# Altium schematic sheet amp.SchDoc(amp)
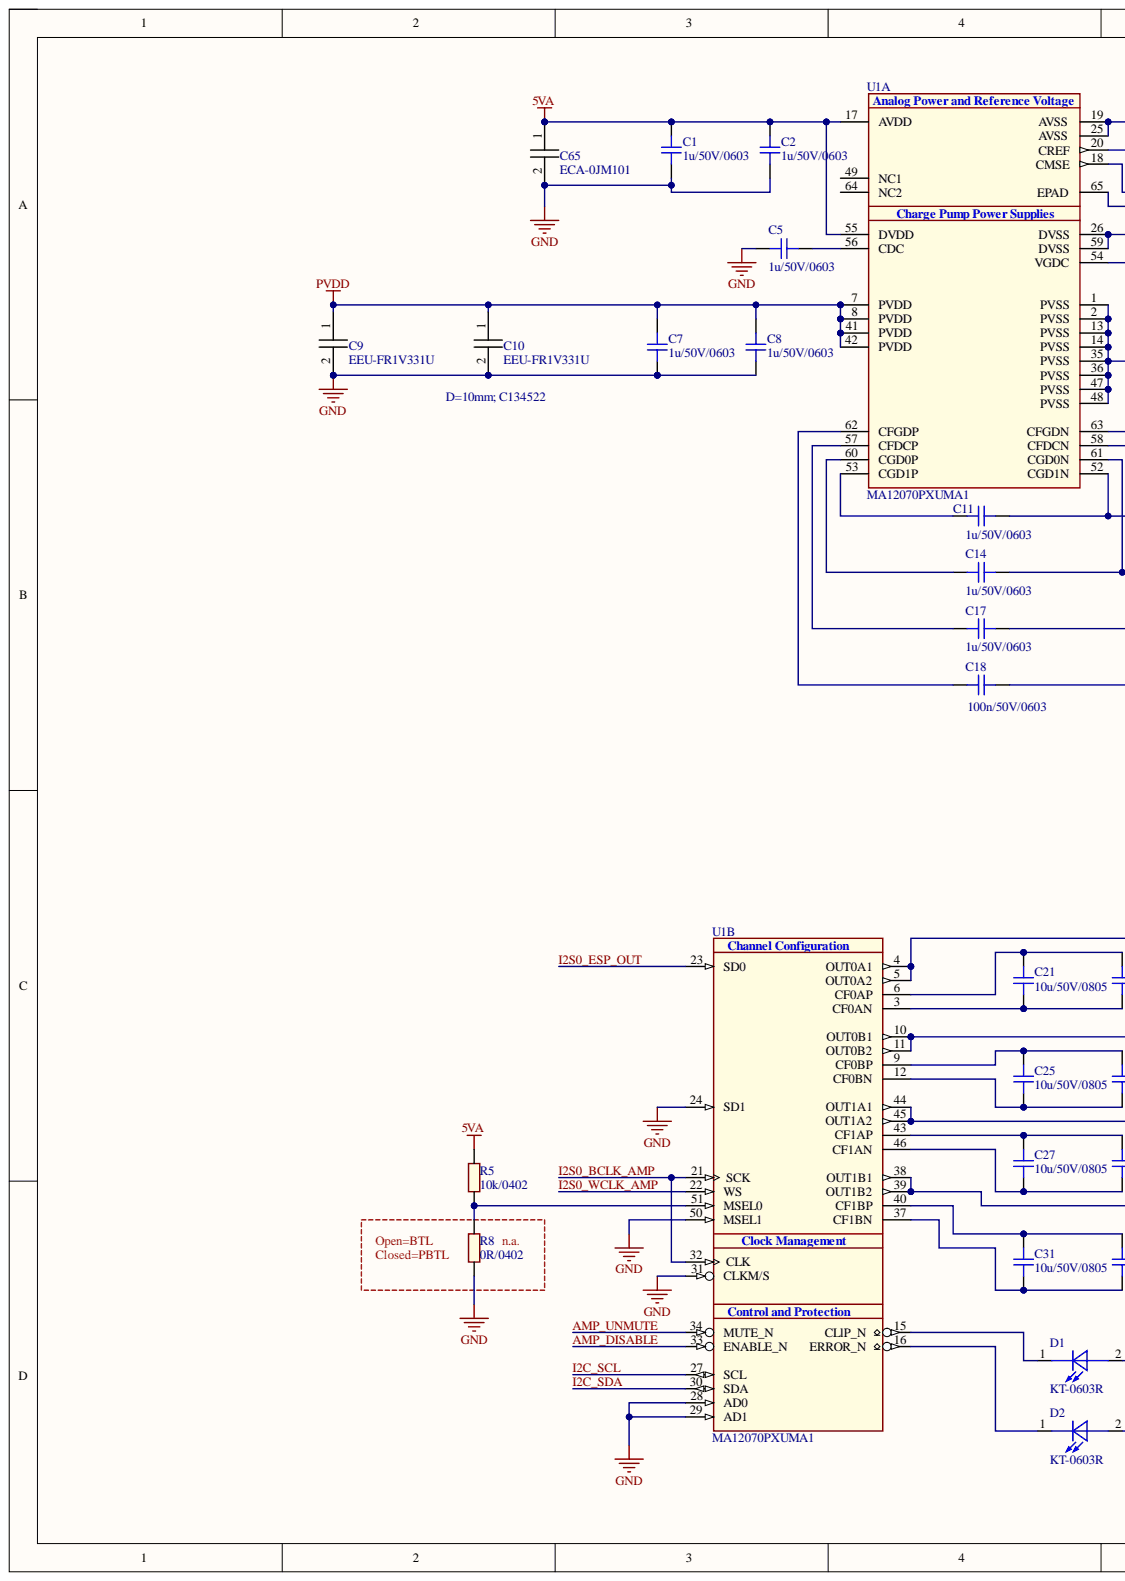
[diagram p0: amp.SchDoc, left]
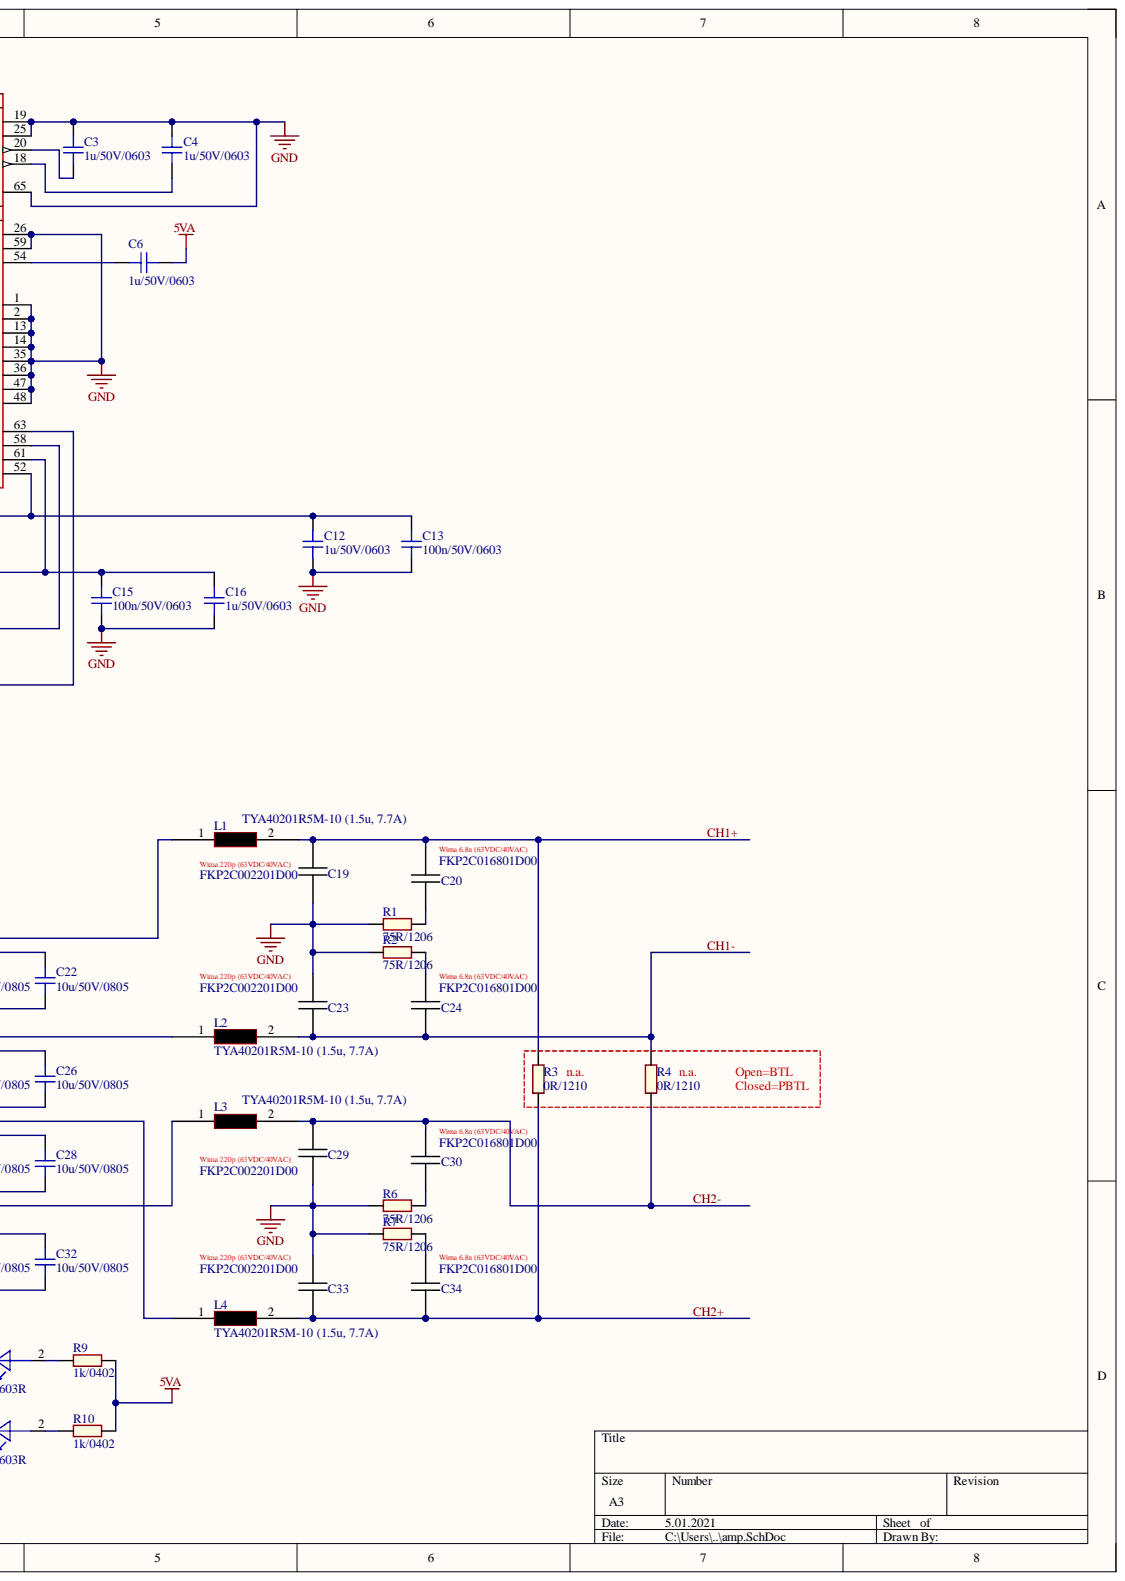
[diagram p1: amp.SchDoc, right]

## Parts
C1  1u/50V/0603  [CAPC1608X87N]
C2  1u/50V/0603  [CAPC1608X87N]
C3  1u/50V/0603  [CAPC1608X87N]
C4  1u/50V/0603  [CAPC1608X87N]
C5  1u/50V/0603  [CAPC1608X87N]
C6  1u/50V/0603  [CAPC1608X87N]
C7  1u/50V/0603  [CAPC1608X87N]
C8  1u/50V/0603  [CAPC1608X87N]
C9  EEU-FR1V331U  [CAP_THT_10mm_rm5mm]
C10  EEU-FR1V331U  [CAP_THT_10mm_rm5mm]
C11  1u/50V/0603  [CAPC1608X87N]
C12  1u/50V/0603  [CAPC1608X87N]
C13  100n/50V/0603  [CAPC1608X87N]
C14  1u/50V/0603  [CAPC1608X87N]
C15  100n/50V/0603  [CAPC1608X87N]
C16  1u/50V/0603  [CAPC1608X87N]
C17  1u/50V/0603  [CAPC1608X87N]
C18  100n/50V/0603  [CAPC1608X87N]
C19  FKP2C002201D00  [Wima FKP2 4.5x6x7.2]
C20  FKP2C016801D00  [Wima FKP2 4.5x6x7.2]
C21  10u/50V/0805  [CAPC2013X140N]
C22  10u/50V/0805  [CAPC2013X140N]
C23  FKP2C002201D00  [Wima FKP2 4.5x6x7.2]
C24  FKP2C016801D00  [Wima FKP2 4.5x6x7.2]
C25  10u/50V/0805  [CAPC2013X140N]
C26  10u/50V/0805  [CAPC2013X140N]
C27  10u/50V/0805  [CAPC2013X140N]
C28  10u/50V/0805  [CAPC2013X140N]
C29  FKP2C002201D00  [Wima FKP2 4.5x6x7.2]
C30  FKP2C016801D00  [Wima FKP2 4.5x6x7.2]
C31  10u/50V/0805  [CAPC2013X140N]
C32  10u/50V/0805  [CAPC2013X140N]
C33  FKP2C002201D00  [Wima FKP2 4.5x6x7.2]
C34  FKP2C016801D00  [Wima FKP2 4.5x6x7.2]
C65  ECA-0JM101  [CAP_THT_5mm_rm2mm]
D1  KT-0603R  [LEDSC160X80X80-2N]
D2  KT-0603R  [LEDSC160X80X80-2N]
L1  TYA40201R5M-10 (1.5u, 7.7A)  [Laird TYA4020 series]
L2  TYA40201R5M-10 (1.5u, 7.7A)  [Laird TYA4020 series]
L3  TYA40201R5M-10 (1.5u, 7.7A)  [Laird TYA4020 series]
L4  TYA40201R5M-10 (1.5u, 7.7A)  [Laird TYA4020 series]
R1  75R/1206  [RESC3216X60N]
R2  75R/1206  [RESC3216X60N]
R3  0R/1210  [RESC3225X70N-3]
R4  0R/1210  [RESC3225X70N-3]
R5  10k/0402  [RESC1005X40N]
R6  75R/1206  [RESC3216X60N]
R7  75R/1206  [RESC3216X60N]
R8  0R/0402  [RESC1005X40N]
R9  1k/0402  [RESC1005X40N]
R10  1k/0402  [RESC1005X40N]
U1  MA12070PXUMA1  [QFN50P900X900X90-65N-1]  (parts A,B)

## Nets
5VA: C1.2 C2.2 C6.1 C65.1 R5.2 R9.2 R10.2 U1.17(AVDD) U1.55(DVDD)
GND: C1.1 C2.1 C3.2 C4.2 C5.1 C7.2 C8.2 C9.2 C10.2 C12.1 C13.2 C15.2 C16.1 C19.1 C23.2 C29.1 C33.2 C65.2 R1.1 R2.1 R6.1 R7.1 R8.1 U1.1(PVSS) U1.2(PVSS) U1.13(PVSS) U1.14(PVSS) U1.19(AVSS) U1.24(SD1) U1.25(AVSS) U1.26(DVSS) U1.28(AD0) U1.29(AD1) U1.31(CLKM/S) U1.35(PVSS) U1.36(PVSS) U1.47(PVSS) U1.48(PVSS) U1.50(MSEL1) U1.59(DVSS) U1.65(EPAD)
PVDD: C7.1 C8.1 C9.1 C10.1 U1.7(PVDD) U1.8(PVDD) U1.41(PVDD) U1.42(PVDD)
AMP_DISABLE: U1.33(ENABLE_N)
AMP_UNMUTE: U1.34(MUTE_N)
CH1+: C19.2 C20.2 L1.2 R3.2
CH1-: C23.1 C24.1 L2.2 R4.2
CH2+: C33.1 C34.1 L4.2 R3.1
CH2-: C29.2 C30.2 L3.2 R4.1
I2C_SCL: U1.27(SCL)
I2C_SDA: U1.30(SDA)
I2S0_BCLK_AMP: U1.21(SCK) U1.32(CLK)
I2S0_ESP_OUT: U1.23(SD0)
I2S0_WCLK_AMP: U1.22(WS)
NetC3_1: C3.1 U1.20(CREF)
NetC4_1: C4.1 U1.18(CMSE)
NetC5_2: C5.2 U1.56(CDC)
NetC6_2: C6.2 U1.54(VGDC)
NetC11_1: C11.1 U1.53(CGD1P)
NetC11_2: C11.2 C12.2 C13.1 U1.52(CGD1N)
NetC14_1: C14.1 U1.60(CGD0P)
NetC14_2: C14.2 C15.1 C16.2 U1.61(CGD0N)
NetC17_1: C17.1 U1.57(CFDCP)
NetC17_2: C17.2 U1.58(CFDCN)
NetC18_1: C18.1 U1.63(CFGDN)
NetC18_2: C18.2 U1.62(CFGDP)
NetC20_1: C20.1 R1.2
NetC21_1: C21.1 C22.1 U1.3(CF0AN)
NetC21_2: C21.2 C22.2 U1.6(CF0AP)
NetC24_2: C24.2 R2.2
NetC25_1: C25.1 C26.1 U1.12(CF0BN)
NetC25_2: C25.2 C26.2 U1.9(CF0BP)
NetC27_1: C27.1 C28.1 U1.46(CF1AN)
NetC27_2: C27.2 C28.2 U1.43(CF1AP)
NetC30_1: C30.1 R6.2
NetC31_1: C31.1 C32.1 U1.37(CF1BN)
NetC31_2: C31.2 C32.2 U1.40(CF1BP)
NetC34_2: C34.2 R7.2
NetD1_1: D1.1 U1.15(CLlP_N)
NetD1_2: D1.2 R9.1
NetD2_1: D2.1 U1.16(ERROR_N)
NetD2_2: D2.2 R10.1
NetL1_1: L1.1 U1.4(OUT0A1) U1.5(OUT0A2)
NetL2_1: L2.1 U1.10(OUT0B1) U1.11(OUT0B2)
NetL3_1: L3.1 U1.38(OUT1B1) U1.39(OUT1B2)
NetL4_1: L4.1 U1.44(OUT1A1) U1.45(OUT1A2)
NetR5_1: R5.1 R8.2 U1.51(MSEL0)

Not connected: U1 49(NC1) 64(NC2)

## Library data of the parts
C1-C8 C11-C18 = 251321: CAP / CERA / 100nF / 10V / 5% / X7R (EIA) / -55°C to 125°C / 603(1608) / SMD / -; Manufacturer: Kemet; Manufacturer Order Number: C0603C104J8RAC; Alternate View: Yes; Automotive Qualified: No; Combined Parameters: TC:X7R (EIA);Tol.:-5%/+5%;Vdc:10V; ComponentStatus: Released; Database Table Name: TPartsAll; Legacy DeviceID: CAP00004163; Package Imperial: 603; Package Metric: 1608; PLMStatus: Not Recommended For New Designs; QuickPick: 100nF / 10V / 5% / X7R (EIA) / 0603; Style: Single; Symbol Type: Capacitor; Single; Standard; 2 Pins; Type: Standard; Version Number: V01; Article Number: 251321; Component Kind: Standard; ComponentLink1Description: Vendor WebSite; ComponentLink1URL: http://www.kemet.com; ComponentLink2Description: Datasheet; ComponentLink2URL: http://webnetprod.muc.infineon.com/PCBPortal_Prod/WorkFlows/AltiumComponent/StandardDocumentation?Versionid=251322; Legacy PartNumber: CAP00004163; Library Name: PCBLibraryData.SVNDbLib; Multiple Parts: No; Package Description: 0603; PackageReference: 0603; Part Type: Capacitor; ROHS Compliant: Y; Second Source allowed: Yes; Supplier 1: Farnell; Supplier 2: DigiKey; Supplier 3: Mouser; Supplier Part Number 2: C0603C104J8RACTU-ND; Technology: Ceramic; Temperature max: 125; Temperature min: -55; Temperature Range: Military; Tolerance Neg: 5%; Tolerance Pos: 5%; Value: 100nF; Voltage DC (max): 10V
C9 C10 = CAP_THT_10mm: Component Kind: Standard; Library Name: MarkusLib.IntLib
C19 C20 C23 C24 C29 C30 C33 C34 = Wima FKP 2 (4.5*7.2): Component Kind: Standard; Library Name: MarkusLib.IntLib
C21 C22 C25-C28 C31 C32 = 304206: CAP / CERA / 330nF / 25V / 5% / X8R (EIA) / -55°C to 150°C / 0805(2012) / SMD / -; Manufacturer: MuRata; Manufacturer Order Number: GCM21BR91E334JA37; Multiple Parts: No; Alternate View: Yes; Automotive Qualified: AEC Q200; Combined Parameters: TC:X8R (EIA);Tol.:-5%/+5%;Vdc:25V; ComponentStatus: Released; Database Table Name: TPartsAll; Legacy DeviceID: CAP01009910; Package Imperial: 0805; Package Metric: 2012; PLMStatus: Active; QuickPick: 330nF / 25V / 5% / X8R (EIA) / CAPC2013X140N; Style: Single; Symbol Type: Capacitor; Single; Standard; 2 Pins; Type: Standard; Version Number: V01; Application: Standard; Article Number: 304206; Component Kind: Standard; ComponentLink1Description: Vendor WebSite; ComponentLink1URL: http://www.murata.com/; ComponentLink2Description: Datasheet; ComponentLink2URL: http://webnetprod.muc.infineon.com/PCBPortal_Prod/WorkFlows/AltiumComponent/StandardDocumentation?Versionid=304207; Legacy PartNumber: CAP01009910; Library Name: PCBLibraryData.SVNDbLib; Package Description: Capacitor,non-polarized,Chip;2.00mm L X 1.25mm W X 1.40mm H; PackageReference: CAPC2013X140N; Part Type: Capacitor; ROHS Compliant: Y; Second Source allowed: Yes; Supplier 1: Farnell; Supplier 2: DigiKey; Supplier 3: Mouser; Technology: Ceramic; Temperature max: 150; Temperature min: -55; Temperature Range: Military; Tolerance Neg: 5%; Tolerance Pos: 5%; Value: 330nF; Voltage DC (max): 25V
C65 = CAP_THT_5mm: Component Kind: Standard; Library Name: MarkusLib.IntLib
D1 D2 = 393589: Surface Mount LED, Green, 570nm; Manufacturer: OSRAM Opto Semiconductors; Manufacturer Order Number: LG Q971-KN-1; Automotive Qualified: No; Combined Parameters: Vf:2.2V;If:20mA;Ur:12V;Ir:20nA;Pmax:-; ComponentStatus: Released; Database Table Name: TPartsAll; Legacy DeviceID: DIO01300117; PLMStatus: Obsolete; QuickPick: LED / 0603; Second Source allowed: Yes; Style: Single; Symbol Type: Standard LED Symbol, 1 Cathode, 2 Anode; Version Number: V01; Voltage DC (max): na; Application: Standard; Article Number: 393589; Component Kind: Standard; ComponentLink1Description: Vendor WebSite; ComponentLink1URL: http://www.osram-os.com; ComponentLink2Description: Datasheet; ComponentLink2URL: http://webnetprod.muc.infineon.com/PCBPortal_Prod/WorkFlows/AltiumComponent/StandardDocumentation?Versionid=393590; Legacy PartNumber: DIO01300117; Library Name: PCBLibraryData.SVNDbLib; Package Description: LED, Side Concave, 2 pin, 1.60mm W X 0.80mm L X 0.80mm H; PackageReference: 0603; Part Type: Diode; ROHS Compliant: Y; Supplier 1: Farnell; Supplier 2: DigiKey; Supplier 3: Mouser; Temperature max: 85; Temperature min: -30; Temperature Range: Military; Type: LED; Value: Green
L1-L4 = Laird TYA 4020: Component Kind: Standard; Library Name: MarkusLib.IntLib
R1 R2 R6 R7 = 353457: RES / STD / 536R / 250mW / 1% / 100ppm/K / -55°C to 155°C / 1206 / SMD / -; Manufacturer: Vishay; Manufacturer Order Number: CRCW1206536RFK; Multiple Parts: No; Alternate View: Yes; Automotive Qualified: AEC Q200; Combined Parameters: TCR:100ppm/K;Tol.:-1%/+1%;Vdc:200V;Idc:-;P:250mW; ComponentStatus: Released; Database Table Name: TPartsAll; Legacy DeviceID: RES01802712; PLMStatus: Active; QuickPick: 536R / 1% / 0.25 / 1206; Style: Single; Symbol Type: Resistor; Single; Standard; 2 Pins; Version Number: V01; Voltage DC (max): 200V; Article Number: 353457; Component Kind: Standard; ComponentLink1Description: Vendor WebSite; ComponentLink1URL: http://www.vishay.com; ComponentLink2Description: Datasheet; ComponentLink2URL: http://webnetprod.muc.infineon.com/PCBPortal_Prod/WorkFlows/AltiumComponent/StandardDocumentation?Versionid=353458; Legacy PartNumber: RES01802712; Library Name: PCBLibraryData.SVNDbLib; PackageReference: 1206; Part Type: Resistor; ROHS Compliant: Y; Second Source allowed: Yes; Supplier 1: Farnell; Supplier 2: DigiKey; Supplier 3: Mouser; Technology: Thick Film; Temperature max: 155; Temperature min: -55; Temperature Range: Military; Tolerance Neg: 1%; Tolerance Pos: 1%; Type: Standard; Value: 536R
R3 R4 = 511884: RES / STD / 820mR / 500mW / 1% / 200ppm/K / -55°C to 155°C / 1210 / SMD / -; Manufacturer: Panasonic; Manufacturer Order Number: ERJ14BQFR82U; Multiple Parts: No; Alternate View: Yes; Automotive Qualified: AEC Q200; Combined Parameters: TCR:200ppm/K;Tol.:-1%/+1%;Vdc:-;Idc:-;P:500mW; ComponentStatus: Released; Database Table Name: TPartsAll; PLMStatus: Active; QuickPick: 820mR / 1% / 0.5 / 1210; Style: Single; Symbol Type: Resistor; Single; Standard; 2 Pins; Version Number: V01; Application: Standard; Article Number: 511884; Component Kind: Standard; ComponentLink1Description: Vendor WebSite; ComponentLink1URL: http://panasonic.net/sanyo/index.html; ComponentLink2Description: Datasheet; ComponentLink2URL: http://webnetprod.muc.infineon.com/PCBPortal_Prod/WorkFlows/AltiumComponent/StandardDocumentation?Versionid=511885; Library Name: PCBLibraryData.SVNDbLib; Package Description: Resistor,Chip;3.20mm L X 2.50mm W X 0.70mm H; PackageReference: 1210; Part Type: Resistor; ROHS Compliant: Y; Second Source allowed: Yes; Supplier 1: Farnell; Supplier 2: DigiKey; Supplier 3: Mouser; Supplier Part Number 1: 1717931; Supplier Part Number 2: P15978CT-ND; Supplier Part Number 3: 667-ERJ-14BQFR82U; Technology: Thick Film; Temperature max: 155; Temperature min: -55; Temperature Range: Military; Tolerance Neg: 1%; Tolerance Pos: 1%; Type: Standard; Value: 820mR
R5 R8-R10 = 342167: RES / STD / 10k / 63mW / 1% / 100ppm/K / -55°C to 155°C / 0402 / SMD / -; Manufacturer: Vishay; Manufacturer Order Number: CRCW040210K0FK; Multiple Parts: No; Alternate View: Yes; Automotive Qualified: AEC Q200; Combined Parameters: TCR:100ppm/K;Tol.:-1%/+1%;Vdc:50V;Idc:-;P:63mW; ComponentStatus: Released; Database Table Name: TPartsAll; Legacy DeviceID: RES01800457; PLMStatus: Active; QuickPick: 10k / 1% / 0.063 / 0402; Style: Single; Symbol Type: Resistor; Single; Standard; 2 Pins; Version Number: V01; Voltage DC (max): 50V; Article Number: 342167; Component Kind: Standard; ComponentLink1Description: Vendor WebSite; ComponentLink1URL: http://www.vishay.com; ComponentLink2Description: Datasheet; ComponentLink2URL: http://webnetprod.muc.infineon.com/PCBPortal_Prod/WorkFlows/AltiumComponent/StandardDocumentation?Versionid=342168; Legacy PartNumber: RES01800457; Library Name: PCBLibraryData.SVNDbLib; PackageReference: 0402; Part Type: Resistor; ROHS Compliant: Y; Second Source allowed: Yes; Supplier 1: Farnell; Supplier 2: DigiKey; Supplier 3: Mouser; Technology: Thick Film; Temperature max: 155; Temperature min: -55; Temperature Range: Military; Tolerance Neg: 1%; Tolerance Pos: 1%; Type: Standard; Value: 10k
U1 = 510342: Filterless and High-Efficiency +4V to +26V Audio Amplifier with I2S Digital Input,Output current 25 mA; Manufacturer: Infineon Technologies; Multiple Parts: Yes; Alternate View: No; Combined Parameters: Audio/Video/Graphics -;Vdc min/max:5V/26V;Iout:8A;Fmax:2.93MHz; ComponentStatus: Released; Database Table Name: TPartsAll; Legacy DeviceID: IFX_MA12070P; PLMStatus: Active; QuickPick: PG-VQFN-64; Version Number: V01; Voltage DC (max): na; Application: Audio/Video/Graphics; Article Number: 510342; Automotive Qualified: No; Component Kind: Standard; ComponentLink1Description: Vendor WebSite; ComponentLink1URL: https://www.infineon.com/; ComponentLink2Description: Datasheet; ComponentLink2URL: http://webnetprod.muc.infineon.com/PCBPortal_Prod/WorkFlows/AltiumComponent/StandardDocumentation?Versionid=510343; Library Name: PCBLibraryData.SVNDbLib; Manufacturer Order Number: MA12070P; Package Description: QFN,0.50mm pitch,square;64 pin,9.00mm L X 9.00mm W X 0.90mm H body (w/thermal tab); PackageReference: PG-VQFN-64; Part Type: Integrated Circuit; ROHS Compliant: Y; Second Source allowed: Yes; Supplier 1: Farnell; Supplier 2: DigiKey; Supplier 3: Mouser; Supplier Part Number 1: 2947807; Supplier Part Number 2: MA12070PXUMA1CT-ND; Supplier Part Number 3: 726-MA12070PXUMA1; Symbol Type: Audio Amplifier with I2S Digital Input; Temperature max: 85; Temperature min: 0; Temperature Range: Military; Value: MA12070P
